# Altium schematic sheet SCH_System.SchDoc(SCH_System)
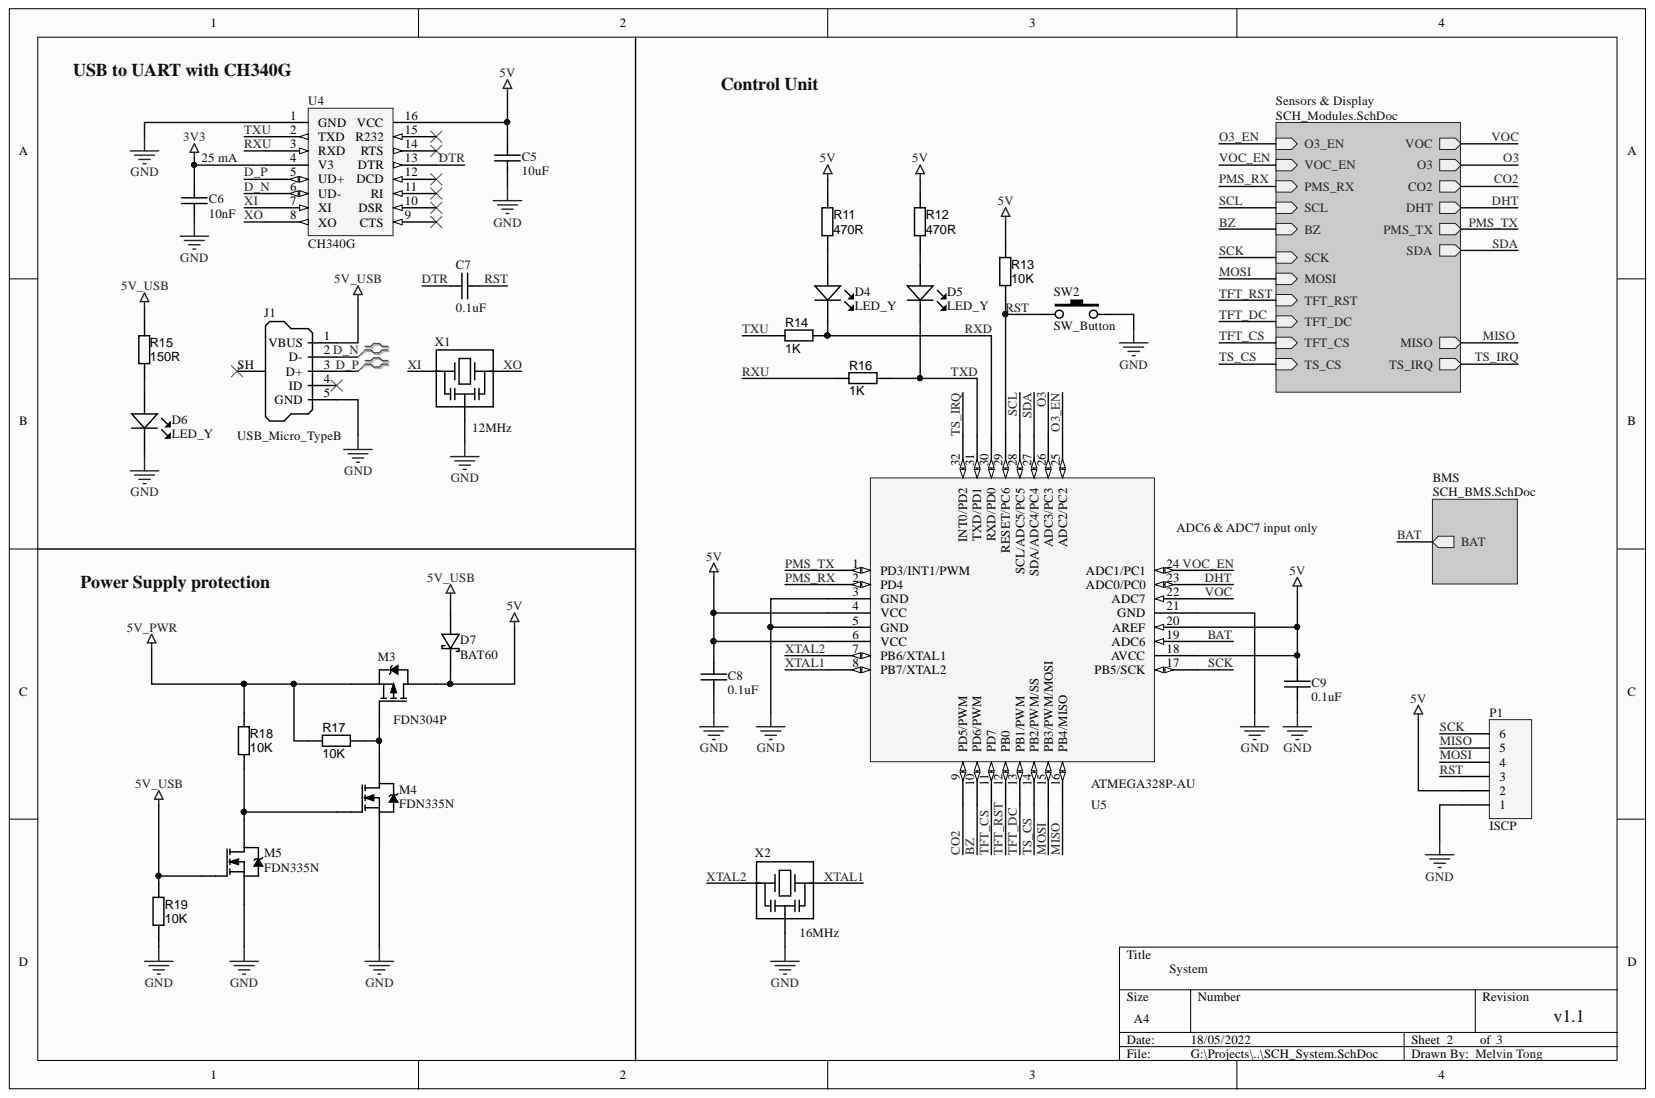
[diagram p0: SCH_System.SchDoc]

## Parts
C5  10uF  [CAP_0805]
C6  10nF  [CAP_0805]
C7  0.1uF  [CAP_0805]
C8  0.1uF  [CAP_0805]
C9  0.1uF  [CAP_0805]
D4  LED_Y  [LED_0805]
D5  LED_Y  [LED_0805]
D6  LED_Y  [LED_0805]
D7  BAT60  [SOD323]
J1  USB_Micro_TypeB  [USB_Micro_TypeB]
M3  FDN304P  [SOT23_213]
M4  FDN335N  [SOT23_213]
M5  FDN335N  [SOT23_213]
P1  ISCP  [HDR1X6]
R11  470R  [RESC3216X70N]
R12  470R  [RESC3216X70N]
R13  10K  [RESC3216X70N]
R14  1K  [RESC3216X70N]
R15  150R  [RESC3216X70N]
R16  1K  [RESC3216X70N]
R17  10K  [RESC3216X70N]
R18  10K  [RESC3216X70N]
R19  10K  [RESC3216X70N]
SW2  SW_Button  [Button_4x4x1.5]
U4  CH340G  [CH340G]
U5  ATMEGA328P-AU  [ATMEGA328P-AU]
X1  12MHz  [XTAL_3.2x1.6]
X2  16MHz  [XTAL_3.2x1.6]

## Nets
3V3: C6.1 U4.4(V3)
5V: C5.1 C8.1 C9.1 D7.K M3.3 P1.2 R11.2 R12.1 R13.1 U4.16(VCC) U5.4(VCC) U5.6(VCC) U5.18(AVCC) U5.20(AREF)
5V_PWR: M3.2 R17.1 R18.1
5V_USB: D7.A J1.1(VBUS) M5.1 R15.1 R19.1
GND: C5.2 C6.2 C8.2 C9.2 D6.K J1.5(GND) M4.2 M5.2 P1.1 R19.2 SW2.2 U4.1(GND) U5.3(GND) U5.5(GND) U5.21(GND) X1.2 X2.2
BAT: U5.19(ADC6)
BZ: U5.10(PD6/PWM)
CO2: U5.9(PD5/PWM)
D_N: J1.2(D-) U4.6(UD-)
D_P: J1.3(D+) U4.5(UD+)
DHT: U5.23(ADC0/PC0)
DTR: C7.1 U4.13(DTR)
MISO: P1.5 U5.16(PB4/MISO)
MOSI: P1.4 U5.15(PB3/PWM/MOSI)
O3: U5.26(ADC3/PC3)
O3_EN: U5.25(ADC2/PC2)
PMS_RX: U5.2(PD4)
PMS_TX: U5.1(PD3/INT1/PWM)
RST: C7.2 P1.3 R13.2 SW2.1 U5.29(RESET/PC6)
RXD: D4.K R14.2 U5.30(RXD/PD0)
RXU: R16.1 U4.3(RXD)
SCK: P1.6 U5.17(PB5/SCK)
SCL: U5.28(SCL/ADC5/PC5)
SDA: U5.27(SDA/ADC4/PC4)
TFT_CS: U5.11(PD7)
TFT_DC: U5.13(PB1/PWM)
TFT_RST: U5.12(PB0)
TS_CS: U5.14(PB2/PWM/SS)
TS_IRQ: U5.32(INT0/PD2)
TXD: D5.K R16.2 U5.31(TXD/PD1)
TXU: R14.1 U4.2(TXD)
VOC: U5.22(ADC7)
VOC_EN: U5.24(ADC1/PC1)
XI: U4.7(XI) X1.1
XO: U4.8(XO) X1.3
XTAL1: U5.8(PB7/XTAL2) X2.3
XTAL2: U5.7(PB6/XTAL1) X2.1
NetD4_A: D4.A R11.1
NetD5_A: D5.A R12.2
NetD6_A: D6.A R15.2
NetJ1_4: J1.4(ID)
NetJ1_SH: J1.SH
NetM3_1: M3.1 M4.3 R17.2
NetM4_1: M4.1 M5.3 R18.2
NetU4_9: U4.9(CTS)
NetU4_10: U4.10(DSR)
NetU4_11: U4.11(RI)
NetU4_12: U4.12(DCD)
NetU4_14: U4.14(RTS)
NetU4_15: U4.15(R232)

## Library data of the parts
C5 = CAP_10uF: SMD 0805; Library Name: SchLib_AirMeter.SchLib; Component Kind: Standard
C6 = CAP_10nF: SMD 0805; Library Name: SchLib_AirMeter.SchLib; Component Kind: Standard
C7-C9 = CAP_0.1uF: SMD 0805; Library Name: SchLib_AirMeter.SchLib; Component Kind: Standard
D4-D6 = LED_Y: SMD_0805 2.4V; Library Name: SchLib_AirMeter.SchLib; Component Kind: Standard
D7 = BAT60: Schottky Diode 3A, Vf~0.24V, Vr=10V; Library Name: SchLib_AirMeter.SchLib; Component Kind: Standard
M3 = PMOS: Library Name: SchLib_AirMeter.SchLib; Component Kind: Standard
M4 M5 = NMOS: Library Name: SchLib_AirMeter.SchLib; Component Kind: Standard
P1 = Header 6: Header, 6-Pin; Signal Integrity: Connector; Library Name: Miscellaneous Connectors.IntLib; LatestRevisionNote: Re-released for DXP Platform.; LatestRevisionDate: 17-Jul-2002; Component Kind: Standard; Publisher: Altium Limited
R11 R12 = RES_470R: Resistor SMD, 1206; Value: N/A; Component Kind: Standard; Library Name: Schlib_Sword.SchLib
R13 R17-R19 = RES_10K: Resistor SMD, 1206; Value: N/A; Library Name: SchLib_AirMeter.SchLib; Component Kind: Standard
R14 R16 = RES_1K: Resistor SMD, 1206; Value: N/A; Library Name: SchLib_AirMeter.SchLib; Component Kind: Standard
R15 = RES_150R: Resistor SMD, 1206; Value: N/A; Library Name: SchLib_AirMeter.SchLib; Component Kind: Standard
X1 = 12MHz: Crystal Oscillator; Library Name: SchLib_AirMeter.SchLib; Component Kind: Standard
X2 = 16MHz: Crystal Oscillator; Library Name: SchLib_AirMeter.SchLib; Component Kind: Standard
J1 = USB_Micro_TypeB: Library Name: SchLib_AirMeter.SchLib; Component Kind: Standard
SW2 = SW_Button: Library Name: SchLib_AirMeter.SchLib; Component Kind: Standard
U4 = CH340G: Library Name: SchLib_AirMeter.SchLib; Component Kind: Standard
U5 = ATMEGA328P-AU: Library Name: SchLib_AirMeter.SchLib; Component Kind: Standard
